# Altium schematic sheet dgm.SchDoc
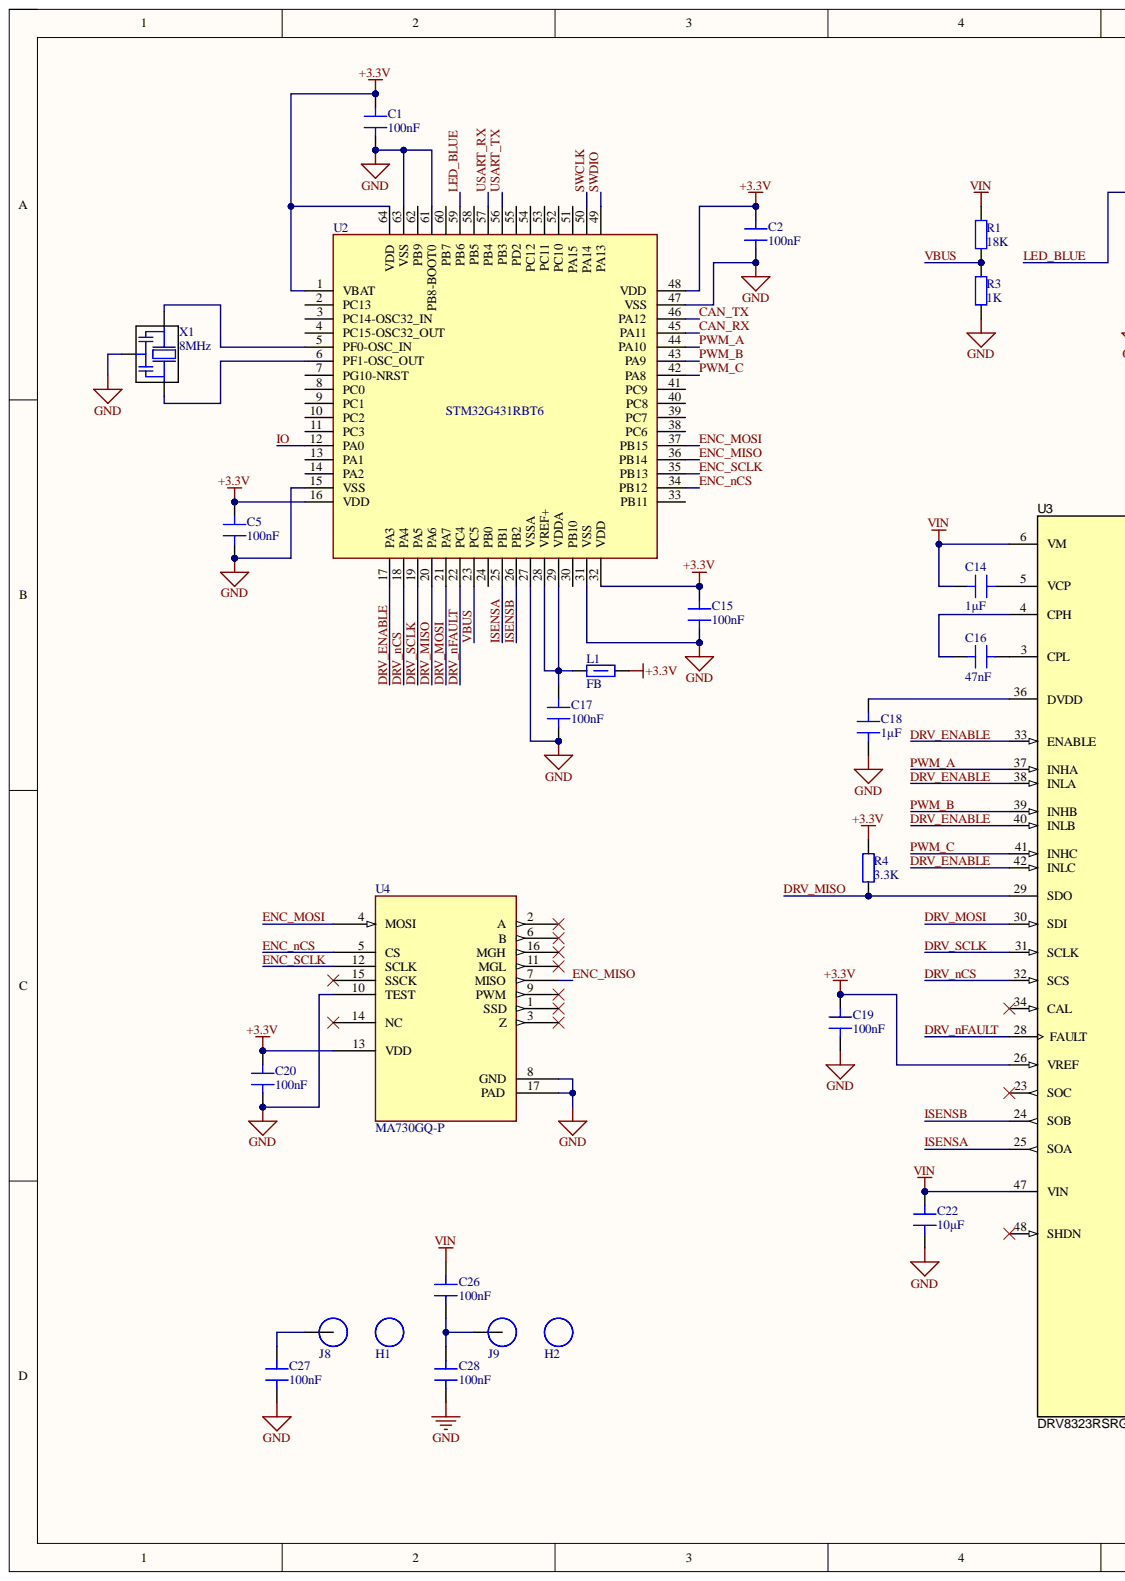
[diagram p0: dgm.SchDoc, left]
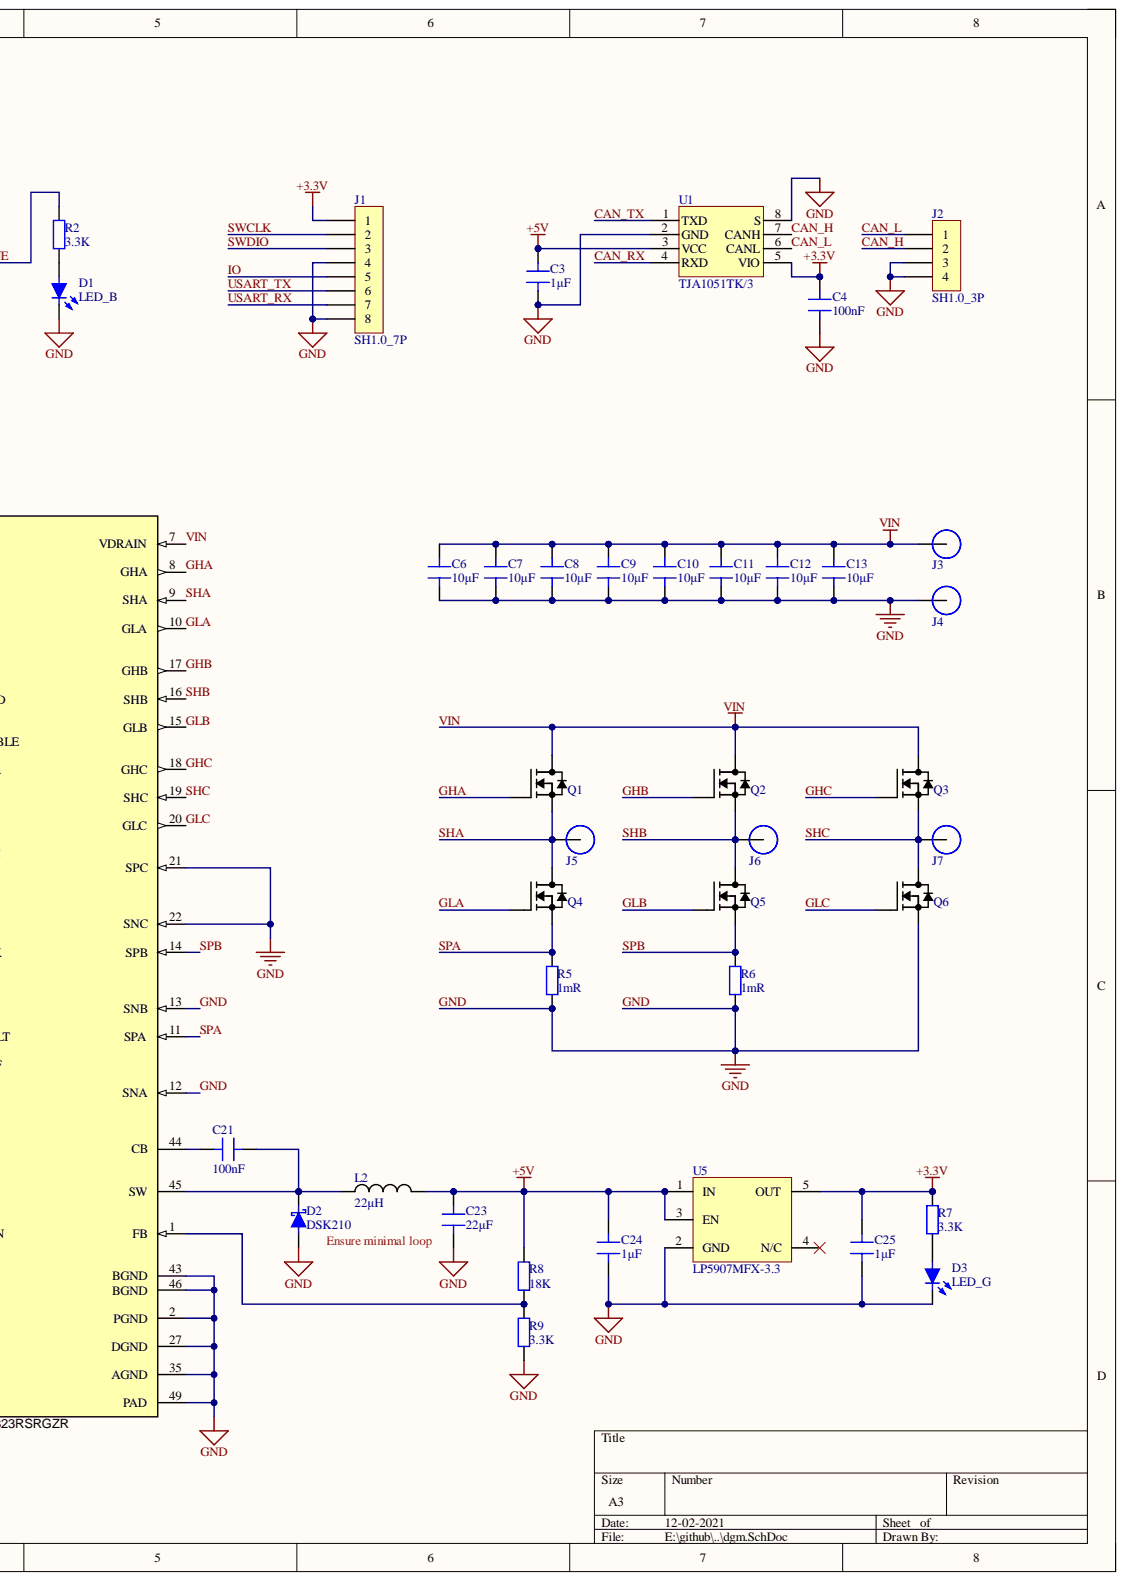
[diagram p1: dgm.SchDoc, right]

## Parts
C1  100nF  [0402_C]
C2  100nF  [0402_C]
C3  1μF  [0402_C]
C4  100nF  [0402_C]
C5  100nF  [0402_C]
C6  10μF  [1206_C]
C7  10μF  [1206_C]
C8  10μF  [1206_C]
C9  10μF  [1206_C]
C10  10μF  [1206_C]
C11  10μF  [1206_C]
C12  10μF  [1206_C]
C13  10μF  [1206_C]
C14  1μF  [0402_C]
C15  100nF  [0402_C]
C16  47nF  [0402_C]
C17  100nF  [0402_C]
C18  1μF  [0402_C]
C19  100nF  [0402_C]
C20  100nF  [0402_C]
C21  100nF  [0603_C]
C22  10μF  [1206_C]
C23  22μF  [0603_C]
C24  1μF  [0402_C]
C25  1μF  [0402_C]
C26  100nF  [0402_C]
C27  100nF  [0402_C]
C28  100nF  [0402_C]
D1  LED_B  [LED_0603_BLUE]
D2  DSK210  [SOD-123F]
D3  LED_G  [LED_0603_GREEN]
H1  M2 Hole  [M2 SPADE HOLE]  (value not drawn)  (no BOM)
H2  M2 Hole  [M2 SPADE HOLE]  (value not drawn)  (no BOM)
J1  SH1.0_7P  [SH1.0_7P]
J2  SH1.0_3P  [SH1.0_3P]
J3  M1.4 Spade Terminal  [M1.4 SPADE HOLE]  (value not drawn)  (no BOM)
J4  M1.4 Spade Terminal  [M1.4 SPADE HOLE]  (value not drawn)  (no BOM)
J5  M1.4 Spade Terminal  [M1.4 SPADE HOLE]  (value not drawn)  (no BOM)
J6  M1.4 Spade Terminal  [M1.4 SPADE HOLE]  (value not drawn)  (no BOM)
J7  M1.4 Spade Terminal  [M1.4 SPADE HOLE]  (value not drawn)  (no BOM)
J8  M2 Spade Terminal  [M2 SPADE HOLE]  (value not drawn)  (no BOM)
J9  M2 Spade Terminal  [M2 SPADE HOLE]  (value not drawn)  (no BOM)
L1  FB  [0603_L]
L2  22μH  [IND_3012]
Q1  NTMFS5C628NLT1G  [SO8FL]  (value not drawn)
Q2  NTMFS5C628NLT1G  [SO8FL]  (value not drawn)
Q3  NTMFS5C628NLT1G  [SO8FL]  (value not drawn)
Q4  NTMFS5C628NLT1G  [SO8FL]  (value not drawn)
Q5  NTMFS5C628NLT1G  [SO8FL]  (value not drawn)
Q6  NTMFS5C628NLT1G  [SO8FL]  (value not drawn)
R1  18K  [0402_R]
R2  3.3K  [0402_R]
R3  1K  [0402_R]
R4  3.3K  [0402_R]
R5  1mR  [1206_R]
R6  1mR  [1206_R]
R7  3.3K  [0402_R]
R8  18K  [0402_R]
R9  3.3K  [0402_R]
U1  TJA1051TK/3  [HVSON-8]
U2  STM32G431RBT6  [STM-LQFP64_N]
U3  DRV8323RSRGZR  [VQFN48]
U4  MA730GQ-P  [QFN-3X3_MA730_MN]
U5  LP5907MFX-3.3  [TSOT-23-5]
X1  8MHz  [3213_3P]

## Nets
+3.3V: C1.2 C2.2 C4.2 C5.2 C15.2 C19.2 C20.2 C25.2 J1.1 L1.1 R4.2 R7.1 U1.5(VIO) U2.1(VBAT) U2.16(VDD) U2.32(VDD) U2.48(VDD) U2.64(VDD) U3.26(VREF) U4.13(VDD) U5.5(OUT)
+5V: C3.1 C23.2 C24.2 L2.2 R8.2 U1.3(VCC) U5.1(IN) U5.3(EN)
CAN_H: J2.2 U1.7(CANH)
CAN_L: J2.1 U1.6(CANL)
CAN_RX: U1.4(RXD) U2.45(PA11)
CAN_TX: U1.1(TXD) U2.46(PA12)
DRV_ENABLE: U2.17(PA3) U3.33(ENABLE) U3.38(INLA) U3.40(INLB) U3.42(INLC)
DRV_MISO: R4.1 U2.20(PA6) U3.29(SDO)
DRV_MOSI: U2.21(PA7) U3.30(SDI)
DRV_nCS: U2.18(PA4) U3.32(SCS)
DRV_nFAULT: U2.22(PC4) U3.28(FAULT)
DRV_SCLK: U2.19(PA5) U3.31(SCLK)
ENC_MISO: U2.36(PB14) U4.7(MISO)
ENC_MOSI: U2.37(PB15) U4.4(MOSI)
ENC_nCS: U2.34(PB12) U4.5(CS)
ENC_SCLK: U2.35(PB13) U4.12(SCLK)
GHA: Q1.G U3.8(GHA)
GHB: Q2.G U3.17(GHB)
GHC: Q3.G U3.18(GHC)
GLA: Q4.G U3.10(GLA)
GLB: Q5.G U3.15(GLB)
GLC: Q6.G U3.20(GLC)
GND: C1.1 C2.1 C3.2 C4.1 C5.1 C6.1 C7.1 C8.1 C9.1 C10.1 C11.1 C12.1 C13.1 C15.1 C17.1 C18.1 C19.1 C20.1 C22.1 C23.1 C24.1 C25.1 C27.1 C28.1 D1.K D2.1 D3.K J1.4 J1.8 J2.3 J2.4 J4.1 Q6.S R3.1 R5.1 R6.1 R9.1 U1.2(GND) U1.8(S) U1.9 U2.15(VSS) U2.27(VSSA) U2.31(VSS) U2.47(VSS) U2.61(PB8-BOOT0) U2.63(VSS) U3.2(PGND) U3.12(SNA) U3.13(SNB) U3.21(SPC) U3.22(SNC) U3.27(DGND) U3.35(AGND) U3.43(BGND) U3.46(BGND) U3.49(PAD) U4.8(GND) U4.10(TEST) U4.17(PAD) U5.2(GND) X1.2
IO: J1.5 U2.12(PA0)
ISENSA: U2.25(PB1) U3.25(SOA)
ISENSB: U2.26(PB2) U3.24(SOB)
LED_BLUE: R2.1 U2.59(PB6)
PWM_A: U2.44(PA10) U3.37(INHA)
PWM_B: U2.43(PA9) U3.39(INHB)
PWM_C: U2.42(PA8) U3.41(INHC)
SHA: J5.1 Q1.S Q4.D U3.9(SHA)
SHB: J6.1 Q2.S Q5.D U3.16(SHB)
SHC: J7.1 Q3.S Q6.D U3.19(SHC)
SPA: Q4.S R5.2 U3.11(SPA)
SPB: Q5.S R6.2 U3.14(SPB)
SWCLK: J1.2 U2.50(PA14)
SWDIO: J1.3 U2.49(PA13)
USART_RX: J1.7 U2.57(PB4)
USART_TX: J1.6 U2.56(PB3)
VBUS: R1.1 R3.2 U2.23(PC5)
VIN: C6.2 C7.2 C8.2 C9.2 C10.2 C11.2 C12.2 C13.2 C14.1 C22.2 C26.2 J3.1 Q1.D Q2.D Q3.D R1.2 U3.6(VM) U3.7(VDRAIN) U3.47(VIN)
NetC14_2: C14.2 U3.5(VCP)
NetC16_1: C16.1 U3.4(CPH)
NetC16_2: C16.2 U3.3(CPL)
NetC17_2: C17.2 L1.2 U2.28(VREF+) U2.29(VDDA)
NetC18_2: C18.2 U3.36(DVDD)
NetC21_1: C21.1 D2.2 L2.1 U3.45(SW)
NetC21_2: C21.2 U3.44(CB)
NetC26_1: C26.1 C28.2 J9.1
NetC27_2: C27.2 J8.1
NetD1_A: D1.A R2.2
NetD3_A: D3.A R7.2
NetR8_1: R8.1 R9.2 U3.1(FB)
NetU2_5: U2.5(PF0-OSC_IN) X1.1
NetU2_6: U2.6(PF1-OSC_OUT) X1.3

Not connected: U2 2(PC13) 3(PC14-OSC32_IN) 4(PC15-OSC32_OUT) 7(PG10-NRST) 8(PC0) 9(PC1) 10(PC2) 11(PC3) 13(PA1) 14(PA2) 24(PB0) 30(PB10) 33(PB11) 38(PC6) 39(PC7) 40(PC8) 41(PC9) 51(PA15) 52(PC10) 53(PC11) 54(PC12) 55(PD2) 58(PB5) 60(PB7) 62(PB9); U3 23(SOC) 34(CAL) 48(SHDN); U4 1(SSD) 2(A) 3(Z) 6(B) 9(PWM) 11(MGL) 14(NC) 15(SSCK) 16(MGH); U5 4(N/C)

## Library data of the parts
C1 C2 C4 C5 C15 C17 C19 C20 C26-C28 = CAP_100nF_0402: CAP CER 100nF 50V X7R 0402; Component Kind: Standard; Library Name: CustomComponents.SchLib
C3 C14 C18 C24 C25 = CAP_1μF_0402: CAP CER 1μF 16V X5R 0402; Component Kind: Standard; Library Name: CustomComponents.SchLib
C6-C13 C22 = CAP_10μF_1206: CAP CER 10μF 50V X7R 1206; Component Kind: Standard; Library Name: CustomComponents.SchLib
C16 = CAP_47nF_0402: CAP CER 47nF 50V X7R 0402; Component Kind: Standard; Library Name: CustomComponents.SchLib
C21 = CAP_100nF_0603: CAP CER 100nF 50V X7R 0603; Component Kind: Standard; Library Name: CustomComponents.SchLib
C23 = CAP_22μF_0603: CAP CER 22μF 10V X5R 0603; Component Kind: Standard; Library Name: CustomComponents.SchLib
D1 = LED_B: LED Blue SMD 0603; Component Kind: Standard; Library Name: CustomComponents.SchLib
D2 = DSK210: Diode DSK210 Diode Schottky SOD-123F C64891; Component Kind: Standard; Library Name: CustomComponents.SchLib
D3 = LED_G: LED Green SMD 0603; Component Kind: Standard; Library Name: CustomComponents.SchLib
H1 H2 = M2 Hole: M2 Hole with no copper; Library Name: CustomComponents.SchLib
J1 = SH1.0_7P: Connector SH1.0_7P; Component Kind: Standard; Library Name: CustomComponents.SchLib
J2 = SH1.0_3P: Connector SH1.0_3P; Component Kind: Standard; Library Name: CustomComponents.SchLib
L1 = FB: FB ferrite bead 600 Ohms@100MHz C85830; Component Kind: Standard; Library Name: CustomComponents.SchLib
L2 = IND_22μH_3012: Inductor 22μH 1A 3012 C350879; Component Kind: Standard; Library Name: CustomComponents.SchLib
Q1-Q6 = NTMFS5C628NLT1G: Mosfet NTMFS5C628NLT1G MOSFET N-CH 60V 60A SO8FL; Component Kind: Standard; Library Name: CustomComponents.SchLib
R1 R8 = RES_18K_0402: RES SMD 18K OHM 1% 1/16W 0402; Component Kind: Standard; Library Name: CustomComponents.SchLib
R2 R4 R7 R9 = RES_3.3K_0402: RES SMD 3.3K OHM 1% 1/16W 0402; Component Kind: Standard; Library Name: CustomComponents.SchLib
R3 = RES_1K_0402: RES SMD 1K OHM 1% 1/16W 0402; Component Kind: Standard; Library Name: CustomComponents.SchLib
R5 R6 = RES_1mR_1206: RES SMD 0.001 OHM 1% 1W ±50ppm/°C 1206 C429334; Component Kind: Standard; Library Name: CustomComponents.SchLib
U1 = TJA1051TK/3: IC High-speed CAN transceiver C124020; Component Kind: Standard; Library Name: CustomComponents.SchLib; Value: TJA1051TK/3
U2 = STM32G431RBT6: IC MCU; Component Kind: Standard; Library Name: CustomComponents.SchLib
U3 = DRV8323RSRGZR: IC 60V Three-Phase Smart Gate Driver; Component Kind: Standard; Library Name: CustomComponents.SchLib
U4 = MA730GQ-P: IC 14-Bit, Digital, Contactless Angle Sensor; Built_By: EMA_Justin; SourceLibrary: Monolithic_Power_Systems_2020-08-10; Vendor: Monolithic Power Systems Inc; Component Kind: Standard; Datasheet: https://www.monolithicpower.com/en/documentview/productdocument/index/version/2/document_type/Datasheet/lang/en/sku/MA800/document_id/3980; Library Name: CustomComponents.SchLib; Manufacturer_Part_Number: MA730GQ-P; RefDes: RefDes; Type: DEV; Copyright: Copyright (C) 2018 Accelerated Designs. All rights reserved
U5 = LP5907MFX-3.3: IC LP5907MFX-3.3 LDO Regulator C80670; Component Kind: Standard; Library Name: CustomComponents.SchLib
X1 = XTAL: XTAL 8MHz 3213; Component Kind: Standard; Library Name: CustomComponents.SchLib
J3-J7 = M1.4 Spade Terminal: Description: M1.4 Spade Terminal; Library Name: CustomComponents.SchLib
J8 J9 = M2 Spade Terminal: Description: M2 Spade Terminal; Library Name: CustomComponents.SchLib
